# Altium schematic sheet FCC Footprint Charge Controller.SchDoc (continued)

## Library data of the parts
C5 = CMP-2006-02945-2: Chip Multilayer Ceramic Capacitors for General Purpose, 0603, 0.10uF, X7R, 15%, 10%, 100V; Capacitance: 100nF; Case Code (Imperial): 0603; Case Code (Metric): 1608; Case/Package: 0603; Component Kind: Standard; Depth: 0.8mm; Dielectric: X7R; Height: 0.8mm; Lead Free: Lead Free; Length: 1.6mm; Library Name: Altium Content Vault; Max Operating Temperature: 125°C; Min Operating Temperature: -55°C; Mount: Surface Mount; Package Quantity: 10000; Packaging: Tape and Reel; Radiation Hardening: No; Termination: SMD/SMT; Thickness: 0.035inch; Tolerance: 10%; Voltage Rating: 100V; Voltage Rating (DC): 100V; Width: 0.031inch
C17 C21 C23 C28 = CMP-2000-06210-2: CAP ALUM 47UF 20% 63V SMD; Dielectric Material: Aluminium; ESR (Equivalent Series Resistance): 650mR; Leakage Current: 3uA; Life (Hours): 2000Hour; Polarity: Polar; Ripple Current: 250mA; Capacitance: 47uF; Case/Package: Radial; Component Kind: Standard; Construction: Cylindrical; Contact Plating: Tin; Diameter: 8mm; Height: 10.2mm; Height - Seated (Max): 0.413inch; Impedance: 650mR; Lead Free: Lead Free; Length: 10.2mm; Library Name: Altium Content Vault; Material: Aluminium; Max Operating Temperature: 105°C; Min Operating Temperature: -55°C; Mount: Surface Mount; Package Quantity: 500; Packaging: Tape and Reel; Radiation Hardening: No; REACH SVHC: No SVHC; Ripple Current (AC): 250mA; RoHS Compliant: Yes; Series: FK; Termination: SMD/SMT; Tolerance: 20%; Voltage: 63V; Voltage Rating: 63V; Voltage Rating (DC): 63V; Width: 10mm
C18 C19 C25 C27 = CMP-2000-07583-2: Cap Ceramic 4.7uF 100V X7S 10% Pad SMD 1210 +125°C Automotive T/R; Capacitance: 4.7uF; Case Code (Imperial): 1210; Case Code (Metric): 3225; Case/Package: 1210; Component Kind: Standard; Composition: Ceramic; Depth: 2.5mm; Dielectric: X7S; Height: 2mm; Lead Free: Lead Free; Length: 3.2mm; Library Name: Altium Content Vault; Max Operating Temperature: 125°C; Min Operating Temperature: -55°C; Mount: Surface Mount; Package Quantity: 1; Packaging: Tape and Reel; Radiation Hardening: No; Ratings: AEC-Q200; REACH SVHC: No SVHC; Termination: SMD/SMT; Thickness: 0.087inch; Tolerance: 10%; Voltage Rating: 100V; Voltage Rating (DC): 100V; Weight: 0.000564oz; Width: 0.098inch
C20 = CMP-08246-000381-1: Cap Ceramic 10uF 25V X7S 10% Pad SMD 0805 +125°C Automotive T/R; Component Kind: Standard; Library Name: Altium Content Vault
C22 = CMP-1035-02595-8: Chip Multilayer Ceramic Capacitors for General Purpose, 0603, 0.10uF, X7R, 15%, 10%, 100V; Capacitance: 100nF; Case Code (Imperial): 0603; Case Code (Metric): 1608; Case/Package: 0603; Component Kind: Standard; Depth: 0.8mm; Dielectric: X7R; Height: 0.8mm; Lead Free: Lead Free; Length: 1.6mm; Library Name: Altium Content Vault; Material: Ceramic; Max Operating Temperature: 125°C; Min Operating Temperature: -55°C; Mount: Surface Mount; Package Quantity: 1; Packaging: Tape and Reel; Radiation Hardening: No; REACH SVHC: No SVHC; Termination: SMD/SMT; Thickness: 0.035inch; Tolerance: 10%; Voltage: 100V; Voltage Rating: 100V; Voltage Rating (DC): 100V; Weight: 0.000222oz; Width: 0.8mm
C24 C29 = CMP-03020-014193-1: CAP CER 0603 1UF 16V X7R 10%; Manufacturer: KEMET; Manufacturer Part Number: C0603C105K4RACAUTO; Automotive Grade: Grade 1; Material: Ceramic; Temperature Coefficient: 15%; Automotive: Yes; Capacitance: 1uF; Category: Cap; Component Kind: Standard; ComponentLink2Description: Datasheet; ComponentLink2URL: https://content.kemet.com/datasheets/KEM_C1023_X7R_AUTO_SMD.pdf; Device Class L1: Passive Components; Device Class L2: Capacitors; Device Class L3: Ceramic Capacitors; Digikey Description: CAP CER 0603 1UF 16V X7R 10%; Height: 0.95mm; Ipc Land Pattern Name: CAPC16080X80; Lead Free: Yes; Library Name: Altium Content Vault; Mouser Description: Cap Ceramic 1uF 16V X7R 10% Pad SMD 0603 125C Automotive T/R; Octopart: https://octopart.com/c0603c105k4racauto-kemet-91328491; Package: C0603C-CJ; RoHS: TRUE; Temperature: -55°C to +125°C; Temperature Characteristic: X7R; Temperature Range High: +125°C; Temperature Range Low: -55°C; Tolerance: 10%; Value: 1uF; Voltage Rating: 16V
C26 = CMP-2006-01966-1: Manufacturer: Vishay; Part Number: VJ0603Y103JXBAT; Component Kind: Standard; Library Name: Altium Content Vault
C30 = CMP-2008-03712-2: Ceramic Capacitor for Automotive 100nF ±10% 16VDC X7R 0402 Paper T/R; Voltage Rating: 16V; Capacitance: 100nF; Case Code (Imperial): 0402; Case Code (Metric): 1005; Case/Package: 0402; Component Kind: Standard; Depth: 0.5mm; Dielectric: X7R; Height: 0.5mm; Lead Free: Lead Free; Length: 1mm; Library Name: Altium Content Vault; Max Operating Temperature: 125°C; Min Operating Temperature: -55°C; Mount: Surface Mount; Package Quantity: 1; Packaging: Tape and Reel; Radiation Hardening: No; Ratings: AEC-Q200; Termination: SMD/SMT; Thickness: 0.022inch; Tolerance: 10%; Voltage Rating (DC): 16V; Width: 0.5mm
C31 C34 = CMP-2008-04663-5: Chip Multilayer Ceramic Capacitors for General Purpose, 0402, 4700pF, X7R, 15%, 10%, 50V; Capacitance: 4.7nF; Case Code (Imperial): 0402; Case Code (Metric): 1005; Case/Package: 0402; Component Kind: Standard; Depth: 0.5mm; Dielectric: X7R; Height: 0.5mm; Lead Free: Lead Free; Length: 1mm; Library Name: Altium Content Vault; Material: Ceramic; Max Operating Temperature: 125°C; Min Operating Temperature: -55°C; Mount: Surface Mount; Package Quantity: 1; Packaging: Tape and Reel; Radiation Hardening: No; REACH SVHC: No SVHC; Termination: SMD/SMT; Thickness: 0.022inch; Tolerance: 10%; Voltage: 50V; Voltage Rating: 50V; Voltage Rating (DC): 50V; Width: 0.02inch
C32 = CMP-2006-02837-2: Chip Multilayer Ceramic Capacitors for General Purpose, 0603, 2.2uF, X7R, 15%, 10%, 10V; Capacitance: 2.2uF; Case Code (Imperial): 0603; Case Code (Metric): 1608; Case/Package: 0603; Component Kind: Standard; Depth: 0.8mm; Dielectric: X7R; Height: 0.8mm; Length: 1.6mm; Library Name: Altium Content Vault; Max Operating Temperature: 125°C; Min Operating Temperature: -55°C; Mount: Surface Mount; Package Quantity: 10000; Packaging: Tape and Reel; Radiation Hardening: No; Termination: SMD/SMT; Thickness: 0.035inch; Tolerance: 10%; Voltage Rating: 10V; Voltage Rating (DC): 10V; Width: 0.031inch
C33 = CMP-2008-03284-2: CAP CER 100PF 50V C0G/NP0 0402; Manufacturer: Kemet; Manufacturer Part Number: C0402C101J5GACTU; Material: Ceramic; Temperature Characteristic: C0G; Temperature Coefficient: 15%; Tolerance: 0.05; Automotive: No; Capacitance: 100 pF; Category: Cap; Component Kind: Standard; ComponentLink2Description: Datasheet; ComponentLink2URL: https://content.kemet.com/datasheets/KEM_C1002_X7R_SMD.pdf; Device Class L1: Passive Components; Device Class L2: Capacitors; Device Class L3: Ceramic Capacitors; Digikey Description: CAP CER 100PF 50V C0G/NP0 0402; Height: 0.55mm; Ipc Land Pattern Name: CAPC10050X50; Lead Free: Yes; Library Name: Altium Content Vault; Mouser Description: Multilayer Ceramic Capacitors MLCC - SMD/SMT 50volts 100pF C0G 5%; Octopart: https://octopart.com/c0402c101j5gactu-kemet-132471; Package: C0402-BB; RoHS: TRUE; Temperature: -55°C to +125°C; Temperature Range High: +125°C; Temperature Range Low: -55°C; Value: 100 pF; Voltage Rating: 50 V
C36 = CMP-06035-000760-1: Cap Ceramic 1uF 100V X7R 10% Pad SMD 1206 Soft Termination  +125°C Automotive T/R; Capacitance: 1uF; Case/Package: 1206; Component Kind: Standard; Features: Soft Termination; Library Name: Altium Content Vault; Max Operating Temperature: 125°C; Min Operating Temperature: -55°C; Mount: Surface Mount; Packaging: Tape and Reel; Ratings: AEC-Q200; Tolerance: 10%; Voltage Rating: 100V
C37 = CMP-2006-02222-2: Cap Ceramic 10000pF 50V X7R 10% Pad SMD 0603 Soft Termination +125°C Automotive T/R; Capacitance: 10nF; Case Code (Imperial): 0603; Case Code (Metric): 1608; Case/Package: 0603; Component Kind: Standard; Depth: 0.8mm; Dielectric: X7R; Features: Soft Termination; Lead Free: Lead Free; Length: 0.063inch; Library Name: Altium Content Vault; Max Operating Temperature: 125°C; Min Operating Temperature: -55°C; Mount: Surface Mount; Package Quantity: 4000; Packaging: Tape and Reel; Radiation Hardening: No; Ratings: AEC-Q200; Termination: SMD/SMT; Thickness: 0.031inch; Tolerance: 10%; Voltage Rating: 50V; Voltage Rating (DC): 50V; Width: 0.031inch
C38 = CMP-2006-03121-2: CAP CER 22PF 25V 10% NP0 0603; Manufacturer: KEMET; Manufacturer Part Number: C0603C220K3GACTU; Automotive Grade: Grade 1; Material: Ceramic; Temperature Characteristic: C0G; Temperature Coefficient: 30ppm/°C; Tolerance: 0.1; Automotive: Yes; Capacitance: 22 pF; Category: Cap; Component Kind: Standard; ComponentLink2Description: Datasheet; ComponentLink2URL: https://api.kemet.com/component-edge/download/datasheet/C0603C100J5GACAUTO.pdf; Device Class L1: Passive Components; Device Class L2: Capacitors; Device Class L3: Ceramic Capacitors; Digikey Description: CAP CER 22PF 25V 10% NP0 0603; Height: 0.87mm; Lead Free: Yes; Library Name: Altium Content Vault; Mouser Description: Multilayer Ceramic Capacitors MLCC - SMD/SMT 25volts 22pF C0G 10%; Octopart: https://octopart.com/c0603c220k3gactu-kemet-9139809; Package: C0603C-CF; RoHS: TRUE; Temperature: -55°C to +125°C; Temperature Range High: +125°C; Temperature Range Low: -55°C; Value: 22 pF; Voltage Rating: 25 V
C39 = CMP-2006-03255-2: CAP CER 680PF 25V 5% NP0 0603; Manufacturer: KEMET; Manufacturer Part Number: C0603C681J3GACTU; Automotive Grade: Grade 1; Material: Ceramic; Temperature Characteristic: C0G; Temperature Coefficient: 30ppm/°C; Tolerance: 0.05; Automotive: Yes; Capacitance: 680 pF; Category: Cap; Component Kind: Standard; ComponentLink2Description: Datasheet; ComponentLink2URL: https://api.kemet.com/component-edge/download/datasheet/C0603C100J5GACAUTO.pdf; Device Class L1: Passive Components; Device Class L2: Capacitors; Device Class L3: Ceramic Capacitors; Digikey Description: CAP CER 680PF 25V 5% NP0 0603; Height: 0.87mm; Lead Free: Yes; Library Name: Altium Content Vault; Mouser Description: 0603 C 680pF Ceramic Multilayer Capacitor; 25 VDC; +125degC; C0G Dielec; +/-5%; Octopart: https://octopart.com/c0603c681j3gactu-kemet-9143775; Package: C0603C-CF; RoHS: TRUE; Temperature: -55°C to +125°C; Temperature Range High: +125°C; Temperature Range Low: -55°C; Value: 680 pF; Voltage Rating: 25 V
D4 D5 = Schottky-Diode: ki_description: Schottky Diode; Component Kind: Standard; Datasheet: https://diotec.com/de/produktliste/SBD.html; ki_keywords: Schottky; Library Name: schottky-diode.SchLib
D6 = CMP-44529-000027-1: LED GREEN CLEAR CHIP SMD; Dominant Wavelength: 570nm; Forward Current: 20mA; Forward Voltage: 2.1V; Luminous Intensity: 70mcd; Viewing Angle: 120°; Case Code (Imperial): 0603; Case Code (Metric): 1608; Case/Package: 0603; Color: Green; Component Kind: Standard; Height: 0.75mm; Illumination Color: Green; Lead Free: Lead Free; Length: 1.6mm; Lens Color: Clear; Lens Style: Clear; Library Name: Altium Content Vault; Max Operating Temperature: 85°C; Min Operating Temperature: -40°C; Mount: Surface Mount; Package Quantity: 2000; Packaging: Tape and Reel; Test Current: 20mA; Width: 0.8mm
D7 = CMP-44529-000034-1: LED RED CLEAR CHIP SMD; Dominant Wavelength: 630nm; Forward Current: 20mA; Forward Voltage: 1.95V; Luminous Intensity: 700mcd; Viewing Angle: 60°; Case Code (Imperial): 0603; Case Code (Metric): 1608; Case/Package: 0603; Color: Red; Component Kind: Standard; Height: 0.95mm; Illumination Color: Red; Lead Free: Lead Free; Length: 1.6mm; Lens Style: Clear; Library Name: Altium Content Vault; Max Operating Temperature: 85°C; Min Operating Temperature: -40°C; Mount: Surface Mount; Package Quantity: 2000; Packaging: Tape and Reel; Test Current: 20mA; Width: 0.8mm
D8 = MMSZ4691_RHG: 500mW, Surface Mount Zener Diode; MF: Taiwan Semiconductor; MP: MMSZ4691 RHG; Check_prices: https://www.snapeda.com/parts/MMSZ4691%20RHG/Taiwan+Semiconductor/view-part/?ref=eda; Component Kind: Standard; Library Name: MMSZ4691_RHG.SchLib; Package: SOD-123-2 Taiwan Semiconductor; SnapEDA_Link: https://www.snapeda.com/parts/MMSZ4691%20RHG/Taiwan+Semiconductor/view-part/?ref=snap
D9 D10 = CMP-2000-05898-2: DIODE SCHOTTKY 60V 3A SMA; Capacitance: 250pF; Element Configuration: Single; Forward Voltage: 700mV; Max Forward Surge Current (Ifsm): 80A; Peak Reverse Current: 500uA; Polarity: Standard; Average Rectified Current: 3A; Case/Package: SMA; Component Kind: Standard; Contact Plating: Tin; Current Rating: 3A; Forward Current: 3A; Height: 2.3mm; Lead Free: Lead Free; Length: 4.6mm; Library Name: Altium Content Vault; Max Operating Temperature: 150°C; Max Repetitive Reverse Voltage (Vrrm): 60V; Max Reverse Voltage (DC): 60V; Min Operating Temperature: -55°C; Mount: Surface Mount; Number of Pins: 2; Output Current: 3A; Package Quantity: 5000; Packaging: Tape and Reel; Peak Non-Repetitive Surge Current: 80A; Radiation Hardening: No; REACH SVHC: No SVHC; Termination: SMD/SMT; Voltage Rating (DC): 60V; Weight: 0.002258oz; Width: 2.92mm
D11 = CMP-12792-000009-1: DIODE ZENER 15V 300MW SOT23-3; Manufacturer: Diodes Inc.; Forward Current: 10mA; Max Junction Temp: +150°C; Max Reverse Leakage Current: 0.1uA; Max Zener Voltage: 15.6V; Reverse Voltage: 10.5V; Standoff Height: 0.013mm; Zener Impedance: 30Ω; Automotive: No; Category: Diode; Component Kind: Standard; ComponentLink2Description: Datasheet; ComponentLink2URL: https://www.diodes.com/assets/Datasheets/ds18001.pdf; Device Class L1: Discrete Semiconductors; Device Class L2: Diodes; Device Class L3: Zener Diodes; Digikey Description: DIODE ZENER 15V 300MW SOT23-3; Footprint Url: https://www.diodes.com/assets/Package-Files/SOT23.pdf; Height: 1.1mm; Lead Free: Yes; Library Name: Altium Content Vault; Manufacturer Part Number: BZX84C15-7-F; Mouser Description: Zener Diodes 15V 350mW; Nom Zener Voltage: 15V; Octopart: https://octopart.com/bzx84c15-7-f-diodes+inc.-327721; Package: SOT23; Power Dissipation: 300mW; RoHS: TRUE; Temperature: -65°C to +150°C; Temperature Range High: +150°C; Temperature Range Low: -65°C
E4 = TEST_POINT_.156_MILL-MAX_2308: TEST POINT,TURRET,0.064" MTG. HOLE,PCB 0.062" THK; Manufacturer PN: 2308-2-00-80-00-00-07-0; Part Reference: E3; PART_NUMBER: 260-0004; CLASS: IO; FPLIST: MILLMAX2308,MILLMAX2308-2; Inventory Qty: 1142083; Component Kind: Standard; Manufacturer: MILL-MAX; PCB Footprint: MILLMAX2308; Value: TEST POINT
JP1 = CMP-1502-01064-3: NexarPartId: 32855522; Connector Type: Male Pin; Contact Resistance: 20mΩ; Current Rating: 3A; Gender: Male; Number of Contacts: 4; Operating Supply Voltage: 250V; Pitch: 2.54mm; Color: Black; Component Kind: Standard; Contact Material: Copper; Contact Mating Length: 5.9944mm; Contact Plating: Gold; Height: 8.89mm; Lead Free: Lead Free; Lead Pitch: 2.54mm; Library Name: Altium Content Vault; Max Operating Temperature: 105°C; Min Operating Temperature: -40°C; Mount: Through Hole; Number of Pins: 4; Number of Positions: 4; Number of Rows: 1; Orientation: Vertical; Packaging: Bulk; REACH SVHC: Unknown; RoHS: Compliant; Termination: Solder; Voltage Rating: 250V
JP2 = CMP-1502-01062-3: NexarPartId: 32855521; Connector Type: Male Pin; Contact Resistance: 20mΩ; Current Rating: 3A; Gender: Male; Number of Contacts: 3; Operating Supply Voltage: 250V; Pitch: 2.54mm; Color: Black; Component Kind: Standard; Contact Material: Copper; Contact Mating Length: 5.9944mm; Contact Plating: Gold; Height: 8.94mm; Lead Free: Lead Free; Lead Pitch: 2.54mm; Library Name: Altium Content Vault; Max Operating Temperature: 105°C; Min Operating Temperature: -40°C; Mount: Through Hole; Number of Pins: 3; Number of Positions: 3; Number of Rows: 1; Orientation: Vertical; Packaging: Bulk; REACH SVHC: Unknown; RoHS: Compliant; Termination: Solder; Voltage Rating: 250V
L2 = CMP-11268-000144-1: Fixed Inductors 22uH Shld 20% 14A 16mOhms AECQ2; Component Kind: Standard; Inductance: 22uH; Library Name: Altium Content Vault; Packaging: Cut Tape; RoHS Compliant: Yes
P1 P2 = CMP-1712-00002-6: NexarPartId: 20081794; Connector Type: Male Pin; Contact Resistance: 20mΩ; Current Rating: 3A; Gender: Male; Number of Contacts: 2; Operating Supply Voltage: 250V; Pitch: 2.54mm; Color: Black; Component Kind: Standard; Contact Gender: Male; Contact Material: Copper; Contact Mating Length: 5.9944mm; Contact Plating: Gold; Height: 8.94mm; Housing Material: PBT; Lead Free: Lead Free; Lead Pitch: 2.54mm; Library Name: Altium Content Vault; Max Operating Temperature: 125°C; Min Operating Temperature: -40°C; Mount: Through Hole; Number of Pins: 2; Number of Positions: 2; Number of Rows: 1; Orientation: Vertical; Packaging: Bulk; REACH SVHC: No SVHC; RoHS: Compliant; Termination: Through Hole; Voltage Rating: 250V
Q3 Q4 = CMP-00278-87920666-1: NTMFD5C674NLT1G Power MOSFET, Dual N-Channel, 60V, 42A, 14.4 mΩ; Manufacturer Lifecycle Status: ACTIVE; Continuous Drain Current (ID): 11A; Drain to Source Resistance: 16.4mΩ; Gate to Source Voltage (Vgs): 20V; Max Junction Temperature (Tj): 175°C; Number of Channels: 2; Power Dissipation: 3W; Turn-Off Delay Time: 18.6ns; Turn-On Delay Time: 9.4ns; Component Kind: Standard; Drain to Source Breakdown Voltage: 60V; Drain to Source Voltage (Vdss): 60V; Height: 1.1mm; Library Name: Altium Content Vault; Lifecycle Status: Production; Max Operating Temperature: 175°C; Min Operating Temperature: -55°C; NexarPartId: 87920666; RoHS: Compliant; Schedule B: 8541290080, 8541290080
Q7 = CMP-02550-000014-1: MOSFET P-CH 60V 8.6A PPAK SO-8; Manufacturer: Vishay; Continuous Drain Current: -8.6A; Depletion Mode: False; Drain To Source Resistance: 0.0115Ω; Drain To Source Voltage: -60V; Gate Charge At Vgs: 121nC @ -10V; Gate To Source Voltage: 20V; Max Forward Diode Voltage: -1.2V; Max Junction Temp: +150°C; Number Of Channels: 1; Power Dissipation: 1.9W; Pulse Drain Current: -60A; Reverse Recovery Time: 45ns; Rthja Max: 52°C/W; Standoff Height: 0mm; Threshold Vgs Max: -3V; Threshold Vgs Min: -1V; Transconductance: 31S; Turn Off Delay Time: 205ns; Turn On Delay Time: 20ns; Typ Forward Diode Voltage: -0.8V; Automotive: No; Category: Trans; Component Kind: Standard; ComponentLink2Description: Datasheet; ComponentLink2URL: http://www.vishay.com/docs/72567/si7461dp.pdf; Device Class L1: Discrete Semiconductors; Device Class L2: Transistors; Device Class L3: MOSFETs; Digikey Description: MOSFET P-CH 60V 8.6A PPAK SO-8; Height: 1.17mm; Lead Free: Yes; Library Name: Altium Content Vault; Manufacturer Part Number: SI7461DP-T1-E3; Mouser Description: MOSFET 60V 14.4A 5.4W 14.5mohm @ 10V; Number Of P Channels: 1; Octopart: https://octopart.com/si7461dp-t1-e3-vishay-42273025; Package: S17-0173-SINGLE; RoHS: TRUE; Temperature: -55°C to +150°C; Temperature Range High: +150°C; Temperature Range Low: -55°C
R15 R42 = CMP-2003-04761-2: 8 mOhms ±1% 1W Chip Resistor Wide 0612 (1632 Metric), Current Sense Thin Film; Resistance: 8mR; Temperature Coefficient: 350ppm/°C; Case Code (Imperial): 1206; Case Code (Metric): 3216; Case/Package: 1206; Component Kind: Standard; Height: 0.024inch; Lead Free: Lead Free; Length: 0.063inch; Library Name: Altium Content Vault; Max Operating Temperature: 125°C; Max Power Dissipation: 1W; Min Operating Temperature: -55°C; Number of Terminations: 2; Package Quantity: 1; Packaging: Tape and Reel; Power Rating: 1W; Resistor Type: Thin Film; RoHS Compliant: Yes; Series: PRL; Technology: THIN FILM; Termination: SMD/SMT; Tolerance: 1%; Width: 0.126inch
R18 = CMP-2001-04034-1: Manufacturer: Vishay Dale; Part Number: CRCW08055M10JNEA; Component Kind: Standard; Library Name: Altium Content Vault
R19 R20 = CMP-2002-08446-2: RES Thick Film, 2kΩ, 1%, 0.063W, 100ppm/°C, 0402; Max Power Dissipation: 63mW; Resistance: 2kR; Voltage Rating: 50V; Case Code (Imperial): 0402; Case Code (Metric): 1005; Case/Package: 0402; Component Kind: Standard; Composition: Thick Film; Contact Plating: Tin; Depth: 0.5mm; Features: Automotive AEC-Q200; Height: 0.35mm; Lead Free: Lead Free; Length: 1mm; Library Name: Altium Content Vault; Max Operating Temperature: 155°C; Military Standard: Not; Min Operating Temperature: -55°C; Mount: Surface Mount; Number of Pins: 2; Number of Terminations: 2; Package Quantity: 10; Packaging: Tape and Reel; Power Rating: 63mW; Radiation Hardening: No; Temperature Coefficient: 100ppm/°C; Termination: SMD/SMT; Tolerance: 1%; Voltage Rating (DC): 50V; Weight: 2.3E-05oz; Width: 0.5mm
R21 R30 = CMP-2001-04896-1: Manufacturer: Vishay Dale; Part Number: CRCW08050000Z0EA; Component Kind: Standard; Library Name: Altium Content Vault
R22 R24 R32 R38 R46 = CMP-02407-000003-1: RES SMD 20 OHM 1% 1/16W 0402; Manufacturer: Vishay; Manufacturer Part Number: CRCW040220R0FKEDC; Automotive Grade: NA; Power Rating: 0.063W; Resistance: 20Ω; Temperature Coefficient: 100ppm/°C; Value: 20Ω; Voltage Rating: 50V; Automotive: No; Category: Res; Component Kind: Standard; ComponentLink2Description: Datasheet; ComponentLink2URL: http://www.vishay.com/docs/28773/crcwce3.pdf; Composition: Thick Film; Device Class L1: Passive Components; Device Class L2: Resistors; Device Class L3: Chip SMD Resistors; Digikey Description: RES SMD 20 OHM 1% 1/16W 0402; Height: 0.35mm; Ipc Land Pattern Name: RESC10050X30; Lead Free: Yes; Library Name: Altium Content Vault; Mouser Description: Res Thick Film 0402 20 Ohm 1% 0.063W(1/16W) ±100ppm/C Pad SMD T/R; Octopart: https://octopart.com/crcw040220r0fkedc-vishay-75649417; Package: CRCW0402-C-e3; RoHS: TRUE; Series: CRCW...C e3; Temperature: -55°C to +155°C; Temperature Range High: +155°C; Temperature Range Low: -55°C; Tolerance: 1%
R23 R35 R39 R41 R53 R55 R59 = CMP-2100-03667-1: Chip Resistor, 0 Ohm, +/- 5%, 0.1 W, -55 to 155 degC, 0603 (1608 Metric), RoHS, Tape and Reel; Manufacturer: Yageo; Power Rating: 100mW; Resistance: 0mOhm; Temperature Coefficient: 200ppm/degreesC; Voltage Rating: 50V; Case Code (Imperial): 0603; Case Code (Metric): 1608; Case/Package: 0603; Component Kind: Standard; Composition: ThickFilm; Contact Plating: Tin; Datasheet URL: http://www.yageo.com/NewPortal/yageodocoutput?fileName=/pdf/R-Chip/PYu-RC_Group_51_RoHS_L_5.pdf; Datasheet Version: Rev. 5, 10/2016; Depth: 0.8mm; Height: 0.45mm; Lead Free: LeadFree; Length: 1.6mm; Library Name: Altium Content Vault; Manufacturer URL: http://www.yageo.com/; Max Operating Temperature: 155degC; Military Standard: Not; Min Operating Temperature: -55degC; Number of Pins: 2; Package Description: 2-Pin Surface Mount Device, Body 1.6 x 0.8 mm; Package Quantity: 5000; Package Reference: 0603; Packaging: TapeandReel; Radiation Hardening: No; REACH SVHC: NoSVHC; RoHSCompliant: true; Termination: SMD/SMT; Tolerance: 5%; Voltage Rating (DC): 50V; Width: 0.8mm
R25 = CMP-2002-08364-2: RES Thick Film, 215kΩ, 1%, 0.063W, 100ppm/°C, 0402; Max Power Dissipation: 63mW; Resistance: 215kR; Voltage Rating: 50V; Case Code (Imperial): 0402; Case Code (Metric): 1005; Case/Package: 0402; Component Kind: Standard; Composition: Thick Film; Contact Plating: Tin; Depth: 0.5mm; Features: Automotive AEC-Q200; Height: 0.35mm; Length: 1mm; Library Name: Altium Content Vault; Max Operating Temperature: 155°C; Military Standard: Not; Min Operating Temperature: -55°C; Mount: Surface Mount; Number of Pins: 2; Number of Terminations: 2; Package Quantity: 10; Packaging: Tape and Reel; Power Rating: 63mW; Temperature Coefficient: 100ppm/°C; Termination: SMD/SMT; Tolerance: 1%; Weight: 2.3E-05oz; Width: 0.02inch
R26 = CMP-03412-037830-1: RES SMD 0 OHM JUMPER 1/16W 0402; Max Power Dissipation: 63mW; Resistance: 0mR; Case Code (Imperial): 0402; Case Code (Metric): 1005; Case/Package: 0402; Component Kind: Standard; Features: Moisture Resistant; Height: 0.016inch; Length: 0.039inch; Library Name: Altium Content Vault; Max Operating Temperature: 155°C; Min Operating Temperature: -55°C; Number of Terminations: 2; Packaging: Tape and Reel; Resistor Type: Thick Film; RoHS Compliant: Yes; Width: 0.02inch
R27 = CMP-02407-013091-1: RES 0 OHM JUMPER 2512; Power Rating: 1W; Resistance: 0R; Temperature Coefficient: 275ppm/°C; Case Code (Imperial): 2512; Case Code (Metric): 6432; Case/Package: 2512; Component Kind: Standard; Contact Plating: Tin; Lead Free: Lead Free; Library Name: Altium Content Vault; Max Operating Temperature: 170°C; Min Operating Temperature: -65°C; Packaging: Cut Tape; Radiation Hardening: No; Reach SVHC Compliant: Yes; Resistor Type: Metal Strip; RoHS Compliant: Yes
R28 = CMP-2002-07730-2: RES SMD 51.1K OHM 1% 1/16W 0402; Manufacturer: Yageo; Manufacturer Part Number: RC0402FR-0751K1L; Power Rating: 0.063W; Resistance: 51.1kΩ; Temperature Coefficient: 100ppm/°C; Value: 51.1kΩ; Voltage Rating: 50V; Automotive: No; Category: Res; Component Kind: Standard; ComponentLink2Description: Datasheet; ComponentLink2URL: http://www.yageo.com/documents/recent/PYu-RC_Group_51_RoHS_L_10.pdf; Composition: Thick Film; Device Class L1: Passive Components; Device Class L2: Resistors; Device Class L3: Chip SMD Resistors; Digikey Description: RES SMD 51.1K OHM 1% 1/16W 0402; Footprint Url: http://www.yageo.com/exep/pages/download/literatures/PYu-R_Mount_10.pdf; Height: 0.4mm; Ipc Land Pattern Name: RESC10050X35; Lead Free: Yes; Library Name: Altium Content Vault; Mouser Description: Thick Film Resistors - SMD 51.1K OHM 1%; Octopart: https://octopart.com/rc0402fr-0751k1l-yageo-39800073; Package: RC_L0402; RoHS: TRUE; Series: RC_L; Temperature: -55°C to +155°C; Temperature Range High: +155°C; Temperature Range Low: -55°C; Tolerance: 1%
R29 = CMP-2002-04696-1: Manufacturer: Bourns; Part Number: CR0402-FX-1003GLF; Component Kind: Standard; Library Name: Altium Content Vault
R31 R33 = CMP-03412-035160-2: RES 20Ω 1.00% 0.1W 0603; Max Power Dissipation: 100mW; Resistance: 20R; Temperature Coefficient: 100ppm/°C; Tolerance: 1%; Voltage Rating: 50V; Case Code (Imperial): 0603; Case Code (Metric): 1608; Case/Package: 0603; Component Kind: Standard; Features: Moisture Resistant; Height: 0.45mm; Length: 1.6mm; Library Name: Altium Content Vault; Max Operating Temperature: 155°C; Min Operating Temperature: -55°C; Number of Terminations: 2; Packaging: Tape and Reel; Power Rating: 100mW; Ratings: AEC-Q200; Resistor Type: Thick Film; RoHS Compliant: Yes; Series: AC; Termination: SMD/SMT; Width: 0.8mm
R34 = CMP-13261-004611-1: Res Thick Film 0603 115kΩ 1% 0.1W ±100ppm/°C Molded SMD T/R; Max Power Dissipation: 100mW; Resistance: 115kR; Voltage Rating (DC): 50V; Case Code (Imperial): 0603; Case Code (Metric): 1608; Case/Package: 0603; Component Kind: Standard; Composition: Thick Film; Contact Plating: Tin; Features: Moisture Resistant; Height: 0.022inch; Lead Free: Lead Free; Length: 0.063inch; Library Name: Altium Content Vault; Max Operating Temperature: 155°C; Min Operating Temperature: -55°C; Number of Terminations: 2; Package Quantity: 1; Packaging: Tape and Reel; Power Rating: 100mW; Radiation Hardening: No; Temperature Coefficient: 100ppm/°C; Tolerance: 1%; Width: 0.031inch
R36 R52 = CMP-2002-08122-2: RES Thick Film, 0Ω, 0.063W, 0402; Max Power Dissipation: 63mW; Resistance: 0mR; Temperature Coefficient: 100ppm/°C; Tolerance: 5%; Voltage Rating: 50V; Case Code (Imperial): 0402; Case Code (Metric): 1005; Case/Package: 0402; Component Kind: Standard; Composition: Thick Film; Contact Plating: Tin; Depth: 0.5mm; Features: Automotive AEC-Q200; Height: 0.35mm; Lead Free: Lead Free; Length: 1mm; Library Name: Altium Content Vault; Max Operating Temperature: 155°C; Military Standard: Not; Min Operating Temperature: -55°C; Mount: Surface Mount; Number of Terminations: 2; Package Quantity: 1; Packaging: Tape and Reel; Power Rating: 63mW; Radiation Hardening: No; Termination: SMD/SMT; Voltage Rating (DC): 50V; Weight: 2.3E-05oz; Width: 0.5mm
R37 = CMP-1012-00774-3: RES Thick Film, 226kΩ, 1%, 0.1W, 100ppm/°C, 0603; Power Rating: 100mW; Resistance: 226kR; Voltage Rating: 75V; Case Code (Metric): 1608; Case/Package: 0603; Component Kind: Standard; Composition: Thick Film; Contact Plating: Tin; Depth: 0.85mm; Height: 0.45mm; Lead Free: Lead Free; Length: 1.55mm; Library Name: Altium Content Vault; Max Operating Temperature: 155°C; Military Standard: Not; Min Operating Temperature: -55°C; Number of Pins: 2; Number of Terminations: 2; Package Quantity: 5000; Packaging: Tape and Reel; Radiation Hardening: No; Temperature Coefficient: 100ppm/°C; Termination: SMD/SMT; Tolerance: 1%; Voltage Rating (DC): 75V; Weight: 7.1E-05oz; Width: 0.85mm; Working Voltage: 75V
R40 = CMP-2000-00886-1: Manufacturer: Panasonic; Part Number: ERA3AEB114V; Component Kind: Standard; Library Name: Altium Content Vault
R43-R45 = CMP-2000-03121-2: RES Thick Film, 24.9kΩ, 1%, 0.1W, 100ppm/°C, 0603; Max Power Dissipation: 100mW; Resistance: 24.9kR; Voltage Rating: 75V; Case Code (Imperial): 0603; Case Code (Metric): 1608; Case/Package: 0603; Component Kind: Standard; Composition: Thick Film; Contact Plating: Tin; Depth: 0.85mm; Features: Automotive AEC-Q200; Height: 0.45mm; Lead Free: Lead Free; Length: 1.55mm; Library Name: Altium Content Vault; Max Operating Temperature: 155°C; Military Standard: Not; Min Operating Temperature: -55°C; Mount: Surface Mount; Number of Terminations: 2; Package Quantity: 10; Packaging: Tape and Reel; Power Rating: 100mW; Radiation Hardening: No; Temperature Coefficient: 100ppm/°C; Termination: SMD/SMT; Tolerance: 1%; Voltage Rating (DC): 75V; Weight: 7.1E-05oz; Width: 0.85mm
R47 = CMP-2003-00767-1: Manufacturer: Panasonic; Component Kind: Standard; Library Name: Altium Content Vault; Part Number: ERJ8BWFR015V
R48 R50 = CMP-2002-02912-3: RES SMD 100 OHM 0.1% 1/16W 0402; Max Power Dissipation: 63mW; Power Rating: 62.5mW; Resistance: 100R; Temperature Coefficient: 25ppm/°C; Voltage Rating: 50V; Case Code (Imperial): 0402; Case Code (Metric): 1005; Case/Package: 0402; Component Kind: Standard; Construction: Rectangular; Contact Plating: Tin; Depth: 0.5mm; Features: Moisture Resistant; Height: 0.014inch; Lead Free: Lead Free; Length: 1mm; Library Name: Altium Content Vault; Max Operating Temperature: 125°C; Military Standard: Not; Min Operating Temperature: -55°C; Number of Terminations: 2; Package Quantity: 10000; Packaging: Tape and Reel; Resistor Type: Thin Film; RoHS Compliant: Yes; Series: RT; Size Code: 0402; Termination: SMD/SMT; Tolerance: 0.1%; Voltage Rating (DC): 50V; Weight: 2.3E-05oz; Width: 0.02inch
R49 = CMP-2002-02553-2: RES 100K OHM 1/16W 1% SMD 0402; Manufacturer: Yageo; Manufacturer Part Number: RT0402FRE07100KL; Power Rating: 0.063W; Resistance: 100KΩ; Temperature Coefficient: 50 ppm/°C; Tolerance: 0.01; Value: 100KΩ; Voltage Rating: 50V; Automotive: No; Category: Res; Component Kind: Standard; ComponentLink2Description: Datasheet; ComponentLink2URL: http://www.yageo.com/documents/recent/PYu-RT_1-to-0.01_RoHS_L_9.pdf; Composition: Thin Film; Device Class L1: Passive Components; Device Class L2: Resistors; Device Class L3: Chip SMD Resistors; Digikey Description: RES 100K OHM 1/16W 1% SMD 0402; Footprint Url: http://www.yageo.com/exep/pages/download/literatures/PYu-R_Mount_10.pdf; Height: 0.35mm; Ipc Land Pattern Name: RESC10050X30; Lead Free: Yes; Library Name: Altium Content Vault; Mouser Description: Res Thin Film 0402 100K Ohm 1% 0.063W(1/16W) ???}50ppm/???? Molded SMD Paper T/R; Octopart: https://octopart.com/rt0402fre07100kl-yageo-1444458; Package: RT0402; RoHS: TRUE; Temperature: -55°C to +155°C; Temperature Range High: +155°C; Temperature Range Low: -55°C
R54 = CMP-07231-000535-1: Thick Film Chip Resistors 0603 56.2kΩ 0.1W 1% 100ppm/°C; Max Power Dissipation: 100mW; Resistance: 56.2kR; Voltage Rating: 50V; Case Code (Imperial): 0603; Case Code (Metric): 1608; Case/Package: 0603; Component Kind: Standard; Composition: Thick Film; Contact Plating: Tin; Features: Moisture Resistant; Height: 0.022inch; Length: 0.063inch; Library Name: Altium Content Vault; Max Operating Temperature: 155°C; Min Operating Temperature: -55°C; Mount: Surface Mount; Number of Terminations: 2; Package Quantity: 1; Packaging: Tape and Reel; Power Rating: 100mW; Radiation Hardening: No; Temperature Coefficient: 100ppm/°C; Termination: SMD/SMT; Thickness: 457um; Tolerance: 1%; Width: 0.8mm
R56-R58 = CMP-26527-006032-1: 47.5kΩ ±1% 0.1W 0603 Thick Film Chip Resistor AEC-Q200 compliant; Max Power Dissipation: 100mW; Resistance: 47.5kR; Temperature Coefficient: 100ppm/°C; Case Code (Metric): 1608; Case/Package: 0603; Component Kind: Standard; Construction: Rectangular; Contact Plating: Tin; Depth: 0.8mm; Features: Automotive AEC-Q200; Height: 0.022inch; Lead Free: Lead Free; Length: 1.55mm; Library Name: Altium Content Vault; Max Operating Temperature: 155°C; Military Standard: Not; Min Operating Temperature: -55°C; Mount: Surface Mount; Number of Terminations: 2; Packaging: Tape and Reel; Power Rating: 100mW; Radiation Hardening: No; Resistor Type: Thick Film; RoHS Compliant: Yes; Series: RMCF; Size Code: 0603; Tolerance: 1%; Width: 0.031inch
  R57: Case Code (Imperial): 0603
R60 = CMP-2000-07451-1: Chip Resistor, 0 Ohm, 0.1 W, -55 to 155 degC, 0402 (1005 Metric), RoHS, Tape and Reel; Manufacturer: Panasonic; Max Power Dissipation: 100mW; Resistance: 0mOhm; Temperature Coefficient: 600ppm/��C; Tolerance: 5%; Voltage Rating: 50V; Case Code (Imperial): 0402; Case Code (Metric): 1005; Case/Package: 0402; Component Kind: Standard; Composition: ThickFilm; Contact Plating: Tin; Datasheet URL: https://industrial.panasonic.com/cdbs/www-data/pdf/RDA0000/AOA0000C301.pdf; Datasheet Version: 03/2015; Depth: 0.5mm; Height: 0.35mm; Lead Free: LeadFree; Length: 1mm; Library Name: Altium Content Vault; Manufacturer URL: http://www.panasonic.com/; Max Operating Temperature: 155degC; Military Standard: Not; Min Operating Temperature: -55degC; Mount: SurfaceMount; Mounting Technology: SurfaceMount; Number of Terminations: 2; Package Description: 2-Pin Surface Mount Device, Body 1 x 0.5 mm; Package Quantity: 1; Package Reference: 402; Packaging: TapeandReel; Power Rating: 100mW; Radiation Hardening: No; REACH SVHC: NoSVHC; RoHSCompliant: True; Termination: SMD/SMT; Voltage Rating (DC): 50V; Width: 0.02inch
R61 = CMP-2001-03649-2: RES Thick Film, 1Ω, 1%, 0.125W, 100ppm/°C, 0805; Max Power Dissipation: 125mW; Resistance: 1R; Voltage Rating: 150V; Case Code (Imperial): 0805; Case Code (Metric): 2012; Case/Package: 0805; Component Kind: Standard; Composition: Thick Film; Contact Plating: Tin; Depth: 1.25mm; Features: Automotive AEC-Q200; Height: 0.02inch; Length: 2mm; Library Name: Altium Content Vault; Max Operating Temperature: 155°C; Military Standard: Not; Min Operating Temperature: -55°C; Mount: Surface Mount; Number of Pins: 2; Number of Terminations: 2; Package Quantity: 10; Packaging: Tape and Reel; Power Rating: 125mW; Radiation Hardening: No; Temperature Coefficient: 100ppm/°C; Termination: SMD/SMT; Tolerance: 1%; Weight: 0.000194oz; Width: 0.049inch
TP5 = PAD_STYLE_C: TESTPOINT, PCB COPPER FEATURE; Part Reference: TP4; PART_NUMBER: PCBPADS-8; CLASS: IO; Component Kind: Standard; PCB Footprint: PADSTYLE1; Value: TEST PAD
TP6 = PAD_STYLE_C: TESTPOINT, PCB COPPER FEATURE; Part Reference: TP3; PART_NUMBER: PCBPADS-8; CLASS: IO; Component Kind: Standard; PCB Footprint: PADSTYLE1; Value: TEST PAD
TP7 = PAD_STYLE_C: TESTPOINT, PCB COPPER FEATURE; Part Reference: TP6; PART_NUMBER: PCBPADS-8; CLASS: IO; Component Kind: Standard; PCB Footprint: PADSTYLE1; Value: TEST PAD
TP8 = PAD_STYLE_C: TESTPOINT, PCB COPPER FEATURE; Part Reference: TP5; PART_NUMBER: PCBPADS-8; CLASS: IO; Component Kind: Standard; PCB Footprint: PADSTYLE1; Value: TEST PAD
TP9 = PAD_STYLE_C: TESTPOINT, PCB COPPER FEATURE; Part Reference: TP10; PART_NUMBER: PCBPADS-8; CLASS: IO; Component Kind: Standard; PCB Footprint: PADSTYLE1; Value: TEST PAD
TP10 = PAD_STYLE_C: TESTPOINT, PCB COPPER FEATURE; Part Reference: TP9; PART_NUMBER: PCBPADS-8; CLASS: IO; Component Kind: Standard; PCB Footprint: PADSTYLE1; Value: TEST PAD
TP11 = PAD_STYLE_C: TESTPOINT, PCB COPPER FEATURE; Part Reference: TP1; PART_NUMBER: PCBPADS-8; CLASS: IO; Component Kind: Standard; PCB Footprint: PADSTYLE1; Value: TEST PAD
TP12 = PAD_STYLE_C: TESTPOINT, PCB COPPER FEATURE; Part Reference: TP2; PART_NUMBER: PCBPADS-8; CLASS: IO; Component Kind: Standard; PCB Footprint: PADSTYLE1; Value: TEST PAD
TP13 TP21 = PAD_STYLE_C: TESTPOINT, PCB COPPER FEATURE; PART_NUMBER: PCBPADS-8; CLASS: IO; Component Kind: Standard; PCB Footprint: PADSTYLE1; Value: TEST PAD
TP14 = PAD_STYLE_C: TESTPOINT, PCB COPPER FEATURE; Part Reference: TP12; PART_NUMBER: PCBPADS-8; CLASS: IO; Component Kind: Standard; PCB Footprint: PADSTYLE1; Value: TEST PAD
TP15 = PAD_STYLE_C: TESTPOINT, PCB COPPER FEATURE; Part Reference: TP14; PART_NUMBER: PCBPADS-8; CLASS: IO; Component Kind: Standard; PCB Footprint: PADSTYLE1; Value: TEST PAD
TP16 = PAD_STYLE_C: TESTPOINT, PCB COPPER FEATURE; Part Reference: TP15; PART_NUMBER: PCBPADS-8; CLASS: IO; Component Kind: Standard; PCB Footprint: PADSTYLE1; Value: TEST PAD
TP17 = PAD_STYLE_C: TESTPOINT, PCB COPPER FEATURE; Part Reference: TP16; PART_NUMBER: PCBPADS-8; CLASS: IO; Component Kind: Standard; PCB Footprint: PADSTYLE1; Value: TEST PAD
TP18 = PAD_STYLE_C: TESTPOINT, PCB COPPER FEATURE; Part Reference: TP17; PART_NUMBER: PCBPADS-8; CLASS: IO; Component Kind: Standard; PCB Footprint: PADSTYLE1; Value: TEST PAD
TP19 = PAD_STYLE_C: TESTPOINT, PCB COPPER FEATURE; Part Reference: TP18; PART_NUMBER: PCBPADS-8; CLASS: IO; Component Kind: Standard; PCB Footprint: PADSTYLE1; Value: TEST PAD
TP20 = PAD_STYLE_C: TESTPOINT, PCB COPPER FEATURE; Part Reference: TP19; PART_NUMBER: PCBPADS-8; CLASS: IO; Component Kind: Standard; PCB Footprint: PADSTYLE1; Value: TEST PAD
TP22 = PAD_STYLE_C: TESTPOINT, PCB COPPER FEATURE; Part Reference: TP20; PART_NUMBER: PCBPADS-8; CLASS: IO; Component Kind: Standard; PCB Footprint: PADSTYLE1; Value: TEST PAD
TP23 = PAD_STYLE_C: TESTPOINT, PCB COPPER FEATURE; Part Reference: TP22; PART_NUMBER: PCBPADS-8; CLASS: IO; Component Kind: Standard; PCB Footprint: PADSTYLE1; Value: TEST PAD
U3 = LTC4020EUHF: IC,55V BUCK-BOOST BATTERY CHARGER,38-pin QFN; Manufacturer: LINEAR TECH.; Manufacturer PN: LTC4020EUHF#PBF; Part Reference: U1; EDMVersionID: 819358601; Inventory Qty: 0; PCB Footprint: LTC-UHF-38-1701; CLASS: IC; Component Kind: Standard; Package Size: QFN-38; Package Type: 38-pin QFN; PART_NUMBER: LTC4020EUHF#PBF; Value: LTC4020EUHF
